# Altium schematic sheet usb_phy.SchDoc(usb_phy)
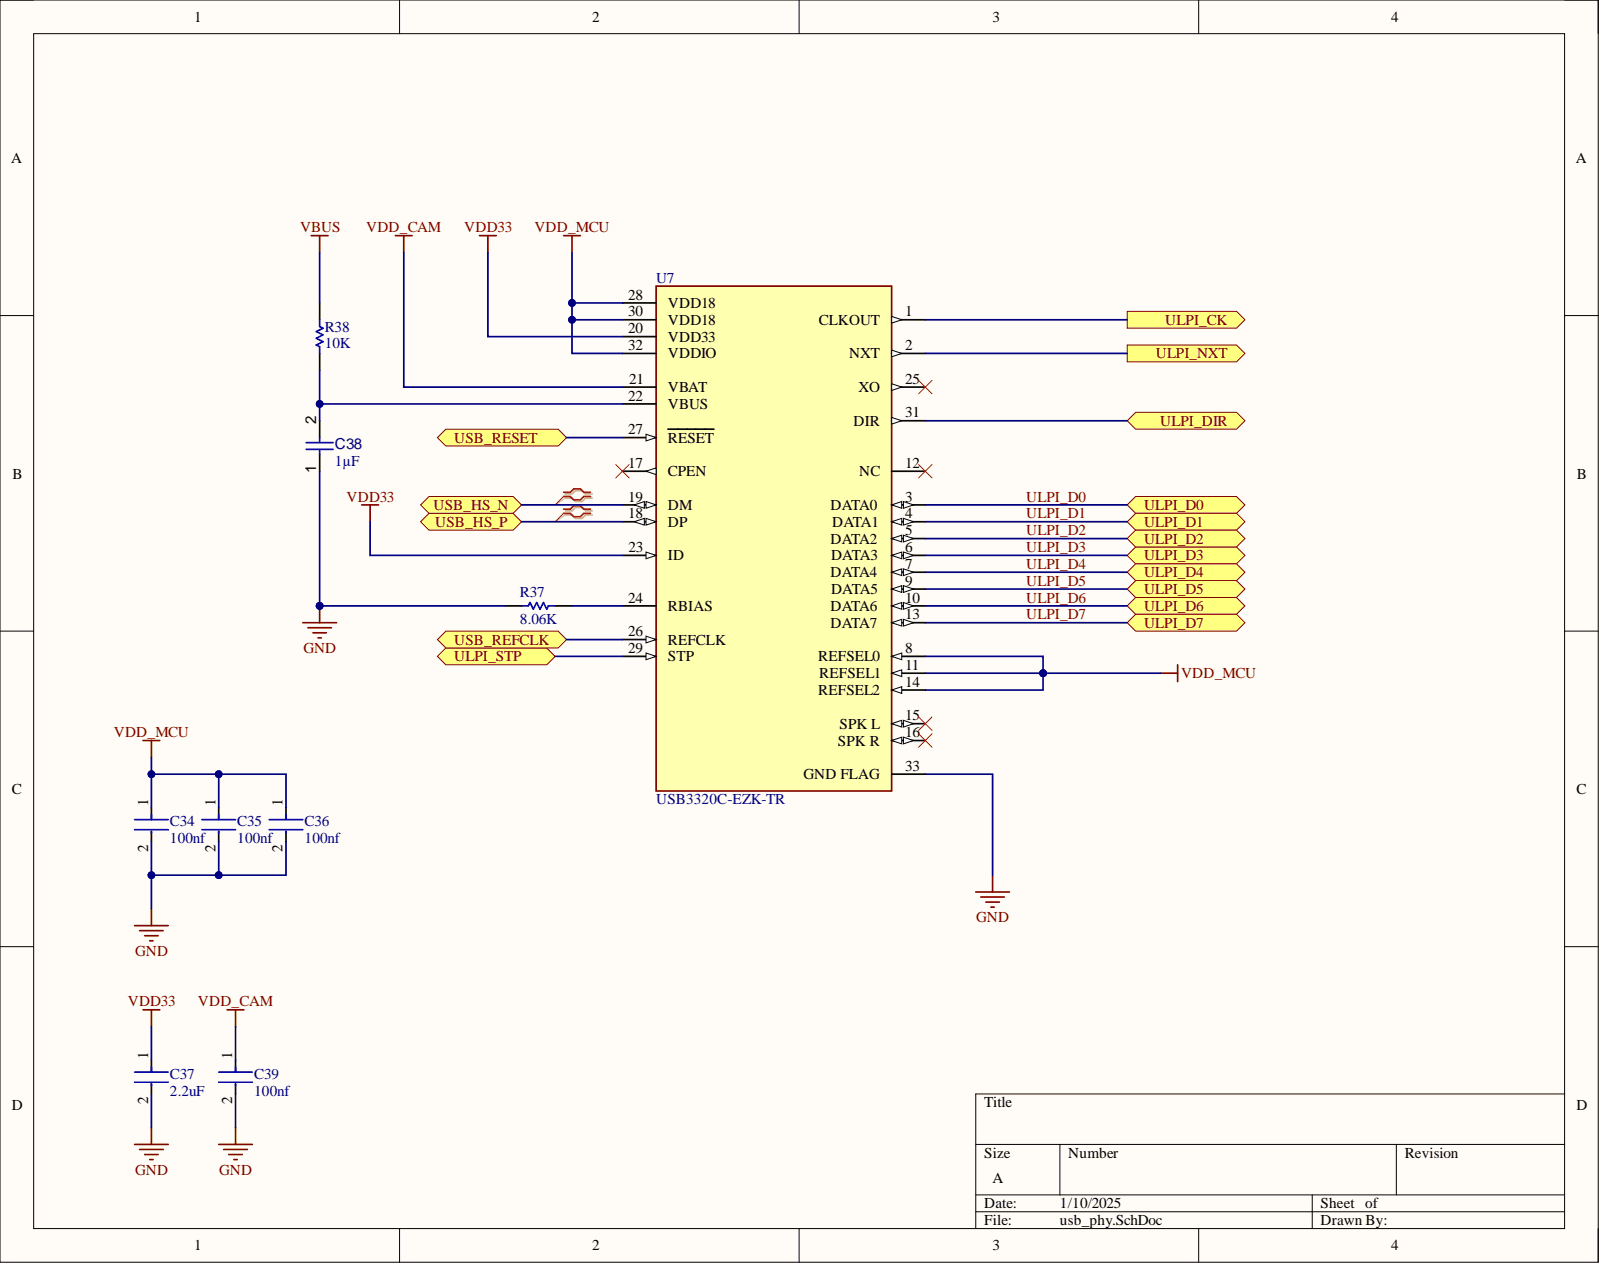
[diagram p0: usb_phy.SchDoc]

## Parts
C34  100nf
C35  100nf
C36  100nf
C37  2.2uF
C38  value 1µF  (hidden comment: Capacitor 1 uF +/- 10% 6.3 V 0402)
C39  100nf
R37  8.06K
R38  10K
U7  USB3320C-EZK-TR

## Ports
ULPI_CK, ULPI_D0, ULPI_D1, ULPI_D2, ULPI_D3, ULPI_D4, ULPI_D5, ULPI_D6, ULPI_D7, ULPI_DIR, ULPI_NXT, ULPI_STP, USB_HS_N, USB_HS_P, USB_REFCLK, USB_RESET

## Nets
GND: C34.2 C35.2 C36.2 C37.2 C38.1 C39.2 R37.1 U7.33(GND_FLAG)
VBUS: R38.1
VDD33: C37.1 U7.20(VDD33) U7.23(ID)
VDD_CAM: C39.1 U7.21(VBAT)
VDD_MCU: C34.1 C35.1 C36.1 U7.8(REFSEL0) U7.11(REFSEL1) U7.14(REFSEL2) U7.28(VDD18) U7.30(VDD18) U7.32(VDDIO)
ULPI_CK: U7.1(CLKOUT) ; port ULPI_CK
ULPI_D0: U7.3(DATA0) ; port ULPI_D0
ULPI_D1: U7.4(DATA1) ; port ULPI_D1
ULPI_D2: U7.5(DATA2) ; port ULPI_D2
ULPI_D3: U7.6(DATA3) ; port ULPI_D3
ULPI_D4: U7.7(DATA4) ; port ULPI_D4
ULPI_D5: U7.9(DATA5) ; port ULPI_D5
ULPI_D6: U7.10(DATA6) ; port ULPI_D6
ULPI_D7: U7.13(DATA7) ; port ULPI_D7
ULPI_DIR: U7.31(DIR) ; port ULPI_DIR
ULPI_NXT: U7.2(NXT) ; port ULPI_NXT
ULPI_STP: U7.29(STP) ; port ULPI_STP
USB_HS_N: U7.19(DM) ; port USB_HS_N
USB_HS_P: U7.18(DP) ; port USB_HS_P
USB_REFCLK: U7.26(REFCLK) ; port USB_REFCLK
USB_RESET: U7.27(RESET) ; port USB_RESET
NetC38_2: C38.2 R38.2 U7.22(VBUS)
NetR37_2: R37.2 U7.24(RBIAS)

Not connected: U7 12(NC) 15(SPK_L) 16(SPK_R) 17(CPEN) 25(XO)

## Library data of the parts
C34-C36 C39 = CMP-13271-001069-1: Cap Ceramic 100nF 10V X7R ±10% SMD 0402 +125°C Paper T/R; Capacitance: 100nF; Case Code (Imperial): 0402; Case Code (Metric): 1005; Case/Package: 0402; Component Kind: Standard; Contact Plating: Tin; Depth: 0.5mm; Dielectric: X7R; Height: 0.5mm; Length: 1mm; Library Name: Altium Content Vault; Max Operating Temperature: 125°C; Min Operating Temperature: -55°C; Mount: Surface Mount; Package Quantity: 1; Packaging: Tape and Reel; Radiation Hardening: No; Thickness: 0.022inch; Tolerance: 10%; Voltage Rating: 10V; Width: 0.02inch
C37 = CMP-03422-000618-1: CAP CER 2.2UF 10V X5R 0402; Manufacturer: YAGEO; Manufacturer Part Number: CC0402KRX5R6BB225; Automotive Grade: NA; Material: Ceramic; Temperature Coefficient: 30ppm/°C; Tolerance: 0.1; Automotive: No; Capacitance: 2.2 uF; Category: Cap; Component Kind: Standard; ComponentLink2Description: Datasheet; ComponentLink2URL: http://www.yageo.com/documents/recent/UPY-GP_NP0_16V-to-50V_16.pdf; Device Class L1: Passive Components; Device Class L2: Capacitors; Device Class L3: Ceramic Capacitors; Digikey Description: CAP CER 2.2UF 10V X5R 0402; Footprint Url: http://www.yageo.com/exep/pages/download/literatures/UPY-C_GEN_24.pdf; Height: 0.55mm; Ipc Land Pattern Name: CAPC10050X50; Lead Free: Yes; Library Name: Altium Content Vault; Mouser Description: CC Series 0402 2.2 uF 10 V ±10% Tolerance X5R Multilayer Ceramic Capacitor; Octopart: https://octopart.com/cc0402krx5r6bb225-yageo-30987418; Package: CC0402; RoHS: TRUE; Temperature: -55°C to +125°C; Temperature Characteristic: X5R; Temperature Range High: +125°C; Temperature Range Low: -55°C; Value: 2.2 uF; Voltage Rating: 10 V
C38 = CMP-001-00008-6: Chip Capacitor, 1 uF, +/- 10%, 6.3 V, 0402 (1005 Metric); Capacitor Type: Ceramic; Voltage Rating: 6.3V; Case/Package: 0402; Component Kind: Standard; Max Operating Temperature: 85°C; Min Operating Temperature: -55°C; Mounting Technology: SM; Pins: 2; RoHS Compliant: True; Tolerance: 10%; Library Name: Openwater
R37 = CMP-2002-07081-1: Manufacturer: Yageo / Phycomp; Part Number: RC0402FR-078K06L; Component Kind: Standard; Library Name: Altium Content Vault
R38 = CMP-2002-07353-1: Manufacturer: Yageo / Phycomp; Part Number: RC0402FR-0710KL; Component Kind: Standard; Library Name: Altium Content Vault
U7 = CMP-0186-00336-2: IC TRANSCEIVER HALF 1/1 32QFN; Manufacturer: Microchip; Interface: UART,USB; Max Supply Voltage: 5.5V; Min Supply Voltage: 1.6V; Nominal Supply Current: 0.7-29400uA; Number Of Ports: 1; Standoff Height: 0mm; Usb Standard: USB 2.0; Ambient Temperature Range High: +85°C; Ambient Temperature Range Low: -40°C; Automotive: No; Category: IC; Component Kind: Standard; ComponentLink2Description: Datasheet; ComponentLink2URL: http://ww1.microchip.com/downloads/en/DeviceDoc/00001792E.pdf; Device Class L1: Integrated Circuits (ICs); Device Class L2: Interface ICs; Device Class L3: USB Interface ICs; Digikey Description: IC TRANSCEIVER HALF 1/1 32QFN; Height: 1mm; Ipc Land Pattern Name: QFN50P500X500X85-32; Lead Free: Yes; Library Name: Altium Content Vault; Manufacturer Part Number: USB3320C-EZK-TR; Mouser Description: Microchip USB3320C-EZK-TR, USB Transceiver, USB 2.0, 1.8 V, 3.3 V, 32-Pin QFN; Octopart: https://octopart.com/usb3320c-ezk-tr-microchip-24995468; Package: 32QFN-3304-5X5B; RoHS: TRUE; Temperature: -40°C to +85°C; Temperature Range High: +85°C; Temperature Range Low: -40°C
